# Altium schematic sheet Standard_Bridge.SchDoc
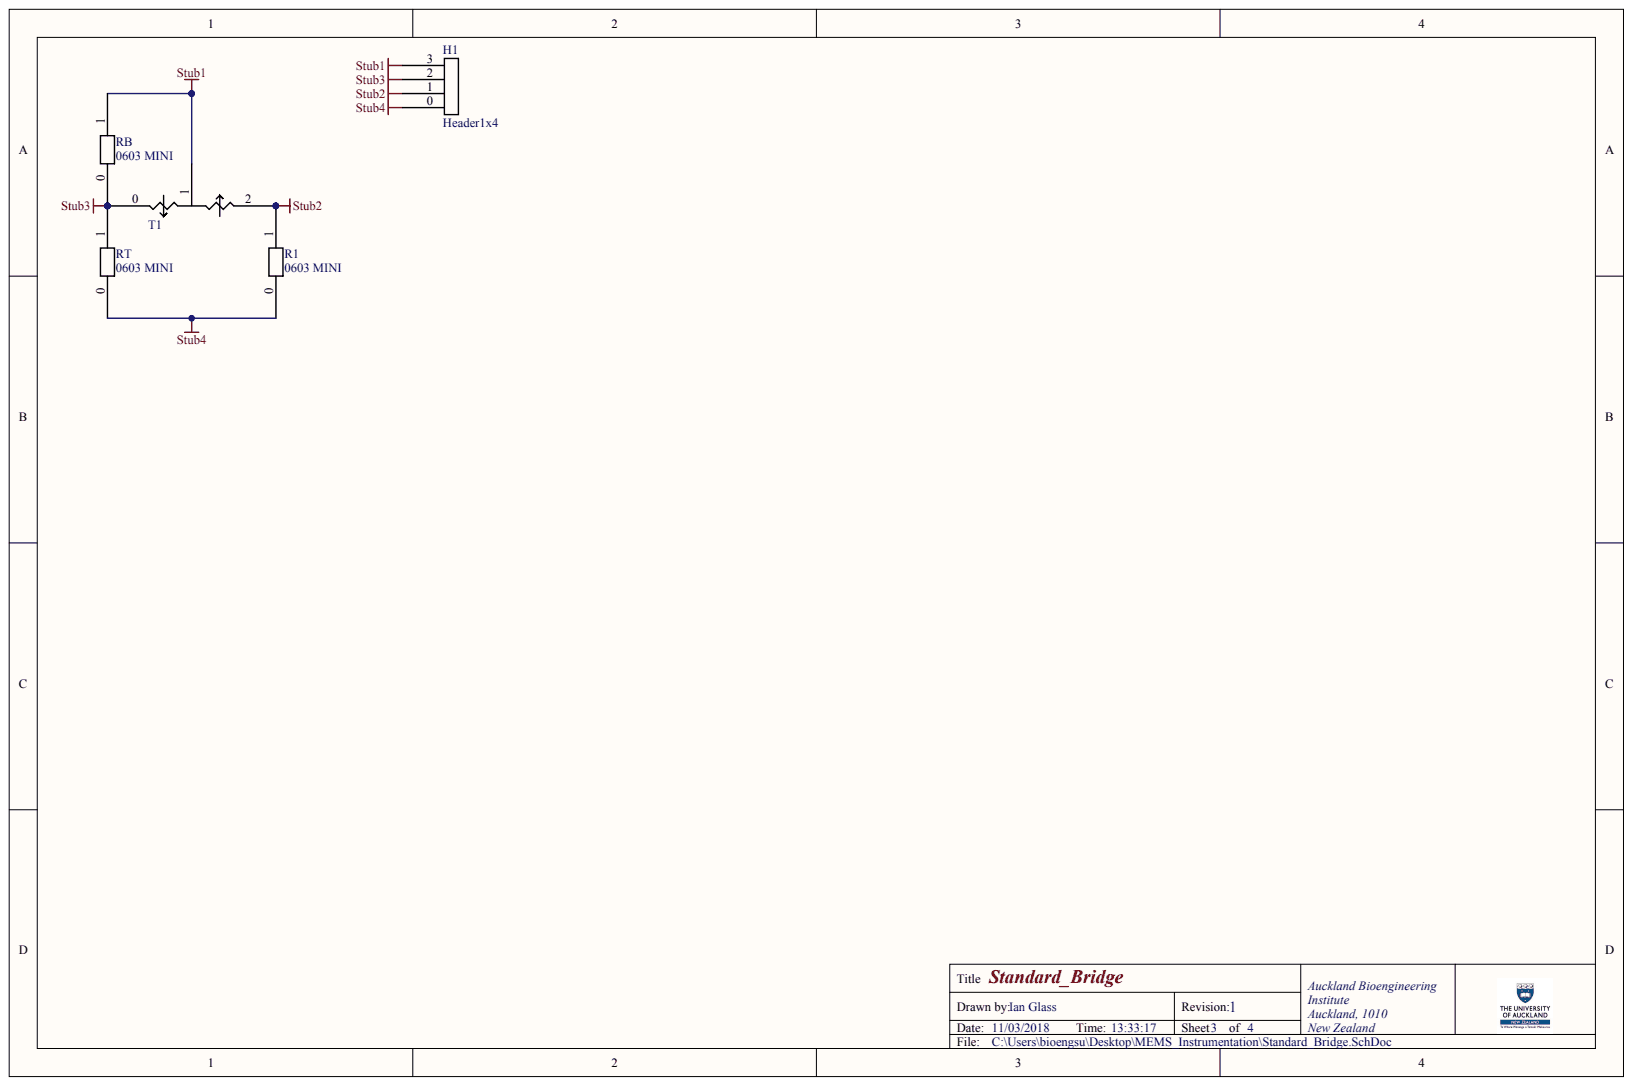
[diagram p0: Standard_Bridge.SchDoc]

## Parts
H1  Header1x4
R1  0603 MINI
RB  0603 MINI
RT  0603 MINI
T1  Transducer  (value not drawn)

## Nets
STUB1: H1.3 RB.1 T1.1
STUB2: H1.1 R1.1 T1.2
STUB3: H1.2 RB.0 RT.1 T1.0
STUB4: H1.0 R1.0 RT.0
